# Altium schematic sheet Sensor Input Circuit.SchDoc("Sensor Input Circuit")
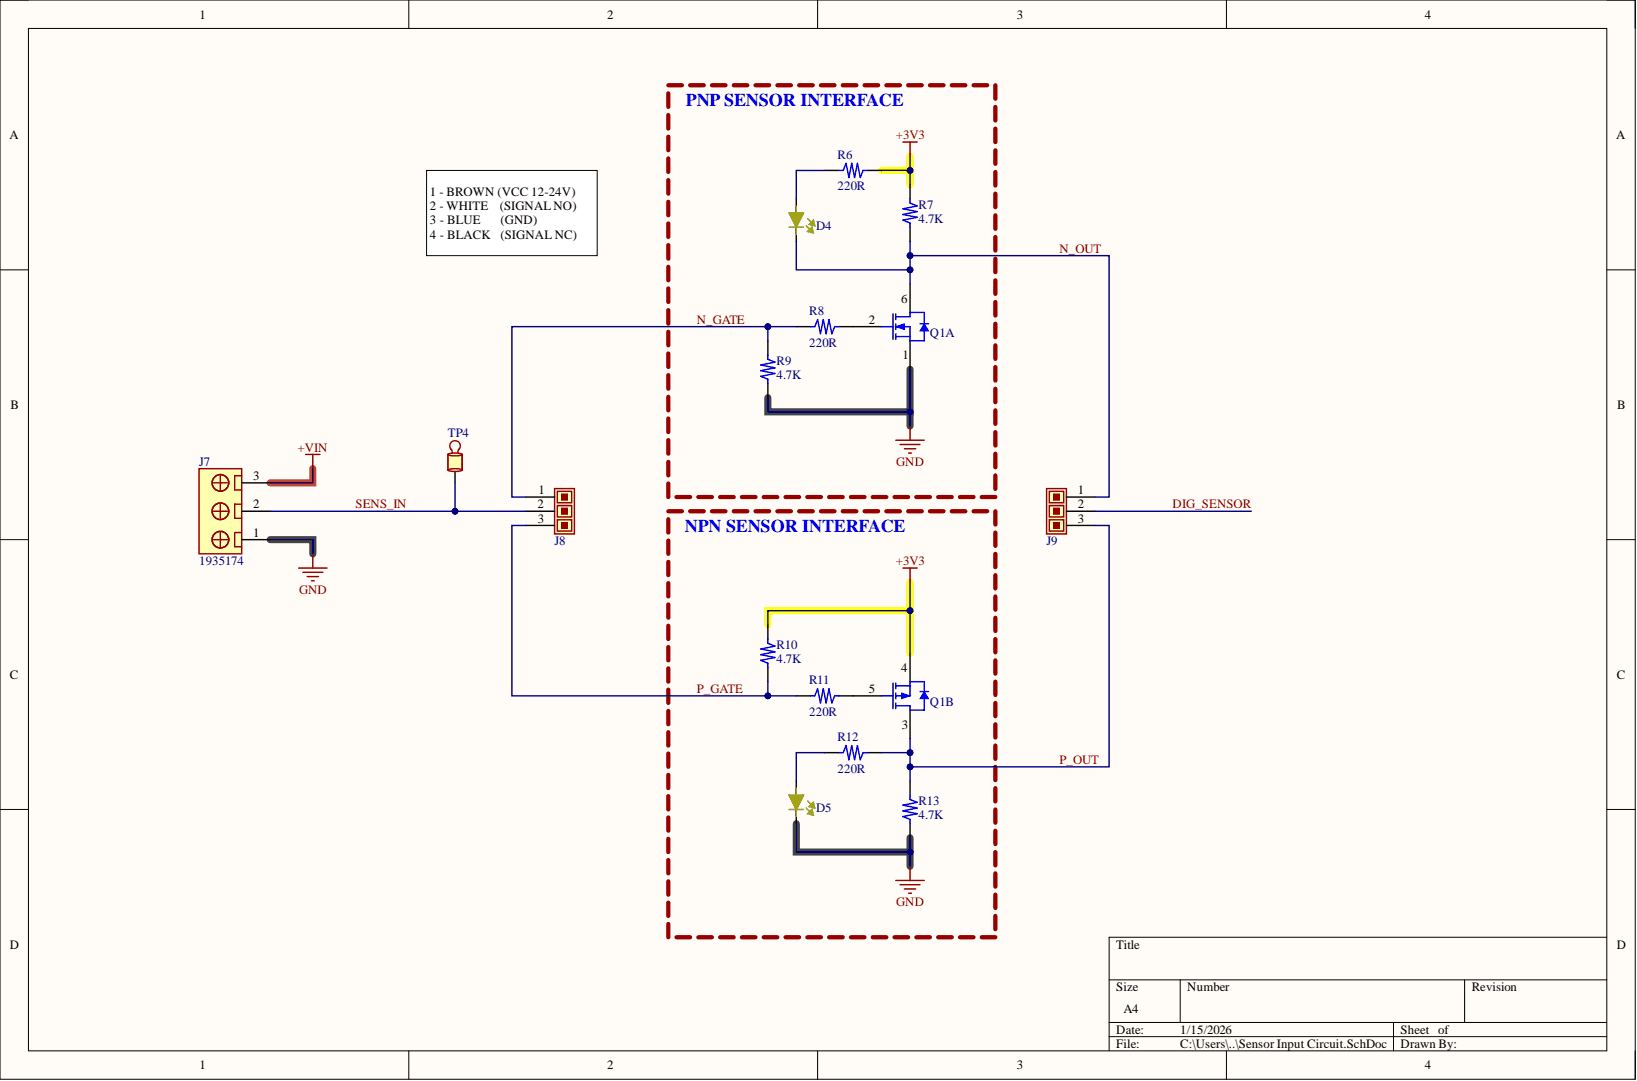
[diagram p0: Sensor Input Circuit.SchDoc]

## Parts
D4  LG R971-KN-1-0-20-R18  [LED0805]  (value not drawn)
D5  LG R971-KN-1-0-20-R18  [LED0805]  (value not drawn)
J7  1935174  [1935174]
J8  68001-203HLF  [3P-2.54mm-MalePins]  (value not drawn)
J9  68001-203HLF  [3P-2.54mm-MalePins]  (value not drawn)
Q1  DMC3400SDW-7  [SOT363]  (value not drawn)  (parts A,B)
R6  value 220R  [RES0603]  (hidden comment: RC0603FR-07220RL)
R7  value 4.7K  [RES0603]  (hidden comment: RC0603FR-074K7L)
R8  value 220R  [RES0603]  (hidden comment: RC0603FR-07220RL)
R9  value 4.7K  [RES0603]  (hidden comment: RC0603FR-074K7L)
R10  value 4.7K  [RES0603]  (hidden comment: RC0603FR-074K7L)
R11  value 220R  [RES0603]  (hidden comment: RC0603FR-07220RL)
R12  value 220R  [RES0603]  (hidden comment: RC0603FR-07220RL)
R13  value 4.7K  [RES0603]  (hidden comment: RC0603FR-074K7L)
TP4  5001  [5001]  (value not drawn)

## Nets
+3V3: Q1.4 R6.1 R7.1 R10.2
+VIN: J7.3
GND: D5.K J7.1 Q1.1 R9.1 R13.1
DIG_SENSOR: J9.2
N_GATE: J8.1 R8.2 R9.2
N_OUT: D4.K J9.1 Q1.6 R7.2
P_GATE: J8.3 R10.1 R11.2
P_OUT: J9.3 Q1.3 R12.1 R13.2
SENS_IN: J7.2 J8.2 TP4.1
NetD4_A: D4.A R6.2
NetD5_A: D5.A R12.2
NetQ1_2: Q1.2 R8.1
NetQ1_5: Q1.5 R11.1

## Library data of the parts
D4 D5 = LG R971-KN-1-0-20-R18: Green 570nm LED Indication - Discrete 2.2V 0805 (2012 Metric); Manufacturer: ams-OSRAM USA INC.; Component Kind: Standard; ComponentLink1Description: Datasheet; ComponentLink1URL: https://look.ams-osram.com/m/2da6f2ceeebf8fac/original/LG-R971.pdf; ComponentLink2Description: DigiKey Link; ComponentLink2URL: https://www.digikey.com/en/products/detail/ams-osram-usa-inc/LG-R971-KN-1-0-20-R18/1227925?s=N4IgTCBcDaIDIHEAEAlAnAdgIwFoDSAcjrgAw5hkpYAcIAugL5A; ComponentLink3Description: LCSC Link; ComponentLink3URL: https://lcsc.com/product-detail/LED-Indication-Discrete_OSRAM-LG-R971-KN-1-0-20-R18_C2899888.html?s_z=n_LG%2520R971-KN-1-0-20-R18; DigiKey PN: 475-LGR971-KN-1CT-ND; LCSC PN: C2899888; Library Name: 05-Optoelectronics.IntLib; MPN: LG R971-KN-1-0-20-R18; Package: 0805
J7 = 1935174: TB 5.00mm 3POS TH 18A, 300V, 12-26 AWG Wire; Manufacturer: Phoenix Contact; Component Kind: Standard; ComponentLink1Description: Datasheet; ComponentLink1URL: https://mm.digikey.com/Volume0/opasdata/d220001/medias/docus/1123/1935174.pdf; ComponentLink2Description: DigiKey Link; ComponentLink2URL: https://www.digikey.com/en/products/detail/phoenix-contact/1935174/568615; ComponentLink3Description: LCSC Link; ComponentLink3URL: https://www.lcsc.com/product-detail/C3816891.html; DigiKey PN: 277-1578-ND; LCSC PN: C3816891; Library Name: 11-Connectors_5.00mm.IntLib; MPN: 1935174; Series: COMBICON PT
J8 J9 = 68001-203HLF: Connector Header Through Hole 3 position 0.100" (2.54mm); Manufacturer: Amphenol ICC (FCI); Component Kind: Standard; ComponentLink1Description: Datasheet; ComponentLink1URL: https://www.waldom.com/upload/parts/datasheets/mf-fci/fci-68001-203hlf.pdf; ComponentLink2Description: DigiKey Link; ComponentLink2URL: https://www.digikey.co.il/en/products/detail/amphenol-cs-fci/68001-203HLF/2023312?s=N4IgTCBcDaIGwA4AMSCMBaMSDMAJAMgGIgC6AvkA; ComponentLink3Description: LCSC Link; ComponentLink3URL: https://lcsc.com/product-detail/Pin-Headers_Amphenol-ICC-68001-203HLF_C5346215.html; DigiKey PN: 609-3470-ND; LCSC PN: C5346215; Library Name: 11-Connectors_2.54mm.IntLib; MPN: 68001-203HLF
Q1 = DMC3400SDW-7: MOSFET N/P-CH 30V 0.65A SOT363; Manufacturer: Diodes Incorporated; Component Kind: Standard; ComponentLink1Description: Datasheet; ComponentLink1URL: https://www.diodes.com/assets/Datasheets/DMC3400SDW.pdf; ComponentLink2Description: DigiKey Link; ComponentLink2URL: https://www.digikey.com/en/products/detail/diodes-incorporated/DMC3400SDW-7/5223201?s=N4IgTCBcDaICIFkDCBmALABgwZTgdQFoB2EAXQF8g; ComponentLink3Description: LCSC Link; ComponentLink3URL: https://lcsc.com/product-detail/MOSFETs_DIODES-DMC3400SDW-7_C155499.html?s_z=n_DMC3400SDW-7; DigiKey PN: DMC3400SDW-7DICT-ND; LCSC PN: C155499; Library Name: 03-Transistors_MOSFETS.IntLib; MPN: DMC3400SDW-7; Package: SOT363
R6 R8 R11 R12 = RC0603FR-07220RL: 220 Ohms ±1% 0.1W, 1/10W Chip Resistor 0603 (1608 Metric) Moisture Resistant Thick Film; Manufacturer: YAGEO; Component Kind: Standard; ComponentLink1Description: Datasheet; ComponentLink1URL: https://www.yageo.com/upload/media/product/products/datasheet/rchip/PYu-RC_Group_51_RoHS_L_12.pdf; ComponentLink2Description: DigiKey Link; ComponentLink2URL: https://www.digikey.com/en/products/detail/yageo/RC0603FR-07220RL/727061?s=N4IgTCBcDaIEoGEAMA2JBmAYnAtEg7GGEnADIgC6AvkA; ComponentLink3Description: LCSC Link; ComponentLink3URL: https://lcsc.com/product-detail/Chip-Resistor-Surface-Mount_YAGEO-RC0603FR-07220RL_C107696.html?s_z=n_RC0603FR-07220RL; DigiKey PN: 311-220HRCT-ND; LCSC PN: C107696; Library Name: 00-Resistors_0603.IntLib; MPN: RC0603FR-07220RL; Package: 0603
R7 R9 R10 R13 = RC0603FR-074K7L: 4.7 kOhms ±1% 0.1W, 1/10W Chip Resistor 0603 (1608 Metric) Moisture Resistant Thick Film; Manufacturer: YAGEO; Component Kind: Standard; ComponentLink1Description: Datasheet; ComponentLink1URL: https://www.yageo.com/upload/media/product/products/datasheet/rchip/PYu-RC_Group_51_RoHS_L_12.pdf; ComponentLink2Description: DigiKey Link; ComponentLink2URL: https://www.digikey.com/en/products/detail/yageo/RC0603FR-074K7L/727212?s=N4IgTCBcDaIEoGEAMA2JBmAYnAtEg7ACwDS%2BAMiALoC%2BQA; ComponentLink3Description: LCSC Link; ComponentLink3URL: https://lcsc.com/product-detail/Chip-Resistor-Surface-Mount_YAGEO-RC0603FR-074K7L_C99782.html?s_z=n_RC0603FR-074K7L; DigiKey PN: 311-4.70KHRCT-ND; LCSC PN: C99782; Library Name: 00-Resistors_0603.IntLib; MPN: RC0603FR-074K7L; Package: 0603
TP4 = 5001: TEST POINT RING, BACK - TH; Manufacturer: Keystone Electronics; Component Kind: Standard; ComponentLink1Description: Datasheet; ComponentLink1URL: https://www.keyelco.com/userAssets/file/M65p56.pdf; ComponentLink2Description: DigiKey Link; ComponentLink2URL: https://www.digikey.com/en/products/detail/keystone-electronics/5001/255327; ComponentLink3Description: LCSC Link; ComponentLink3URL: https://www.lcsc.com/product-detail/C238122.html; DigiKey PN: 36-5001-ND; LCSC PN: C238122; Library Name: 09-TestPoints.IntLib; MPN: 5001
